# Altium schematic sheet TIDA-00367_REV2.SchDoc(TIDA-00367_REV2) (continued)

## Library data of the parts
C1 = QMK212B7102KD-T: CAP, CERM, 1000 pF, 250 V, +/- 10%, X7R, 0805; Manufacturer: Taiyo Yuden; Cap_Case: 0805; Cap_F: 0.000000001; CompType: Capacitor; Polarized: N; TaMax_C: 125; TaMin_C: -55; Tolerance_%: 10; U/M: EA; Value1: Unknown; Value2: 250V; VDC_V: 250; Component Kind: Standard; ComponentLink1Description: Datasheet link; ComponentLink1URL: http://www.yuden.co.jp/ut/product/pdf/dhmk_e.pdf; LatestRevisionDate: 2015-07-23; Library Name: Capacitor_Ceramic.IntLib; MountType: SMT; PackageReference: 0805; PartNumber: QMK212B7102KD-T; Published: 2008-06-19; RoHS: Y; Signal Integrity: Cap; Simulation: Cap; Supplier 1: Digi-Key; Supplier 2: Farnell; Supplier 3: Newark; Supplier Part Number 1: 587-1268-1-ND; Supplier Part Number 2: 1683683; Supplier Part Number 3: 77M8475; SymbolVersion: 2008-07-09; Technology: Ceramic; TempCo: X7R; ValueDisplayed: 1000pF; Publisher: Texas Instruments
C2 C18 C19 = DE1E3KX102MA5BA01: CAP, CERM, 1000 pF, 250 V, +/- 20%, E, Disc, 8x12mm; Manufacturer: MuRata; Cap_Case: DE_800x1200x800; Cap_F: 0.000000001; CompType: Capacitor; Polarized: N; TaMax_C: 85; TaMin_C: -25; Tolerance_%: 20; U/M: EA; Value1: Unknown; Value2: 250V; VDC_V: 250; Component Kind: Standard; ComponentLink1Description: Datasheet link; ComponentLink1URL: http://psearch.murata.com/capacitor/product/DE1E3KX102MA5BA01.pdf; LatestRevisionDate: 2014-12-18; Library Name: Capacitor_Ceramic.IntLib; MountType: TH; PackageReference: Disc, 8x12mm; PartNumber: DE1E3KX102MA5BA01; Published: 2008-06-19; RoHS: Y; Signal Integrity: Cap; Simulation: Cap; Supplier 1: Digi-Key; Supplier 2: Mouser; Supplier Part Number 1: 490-7045-ND; Supplier Part Number 2: 81-DE1E3KX102MA5BA01; SymbolVersion: 2008-07-09; Technology: Ceramic; TempCo: E; ValueDisplayed: 1000pF; Publisher: Texas Instruments
C3 = B32521C3474K: CAP FILM 0.47UF 20% 630VDC RAD; Manufacturer: TDK; Cap_Case: Radial 13x6x12mm; Cap_F: 0.00000047; CompType: Capacitor; Polarized: N; TaMax_C: 125; TaMin_C: -55; Tolerance_%: 10; U/M: EA; Value1: Unknown; Value2: 630V; VDC_V: 630; Component Kind: Standard; ComponentLink1Description: Datasheet link; ComponentLink1URL: http://en.tdk.eu/inf/20/20/db/fc_2009/B32520_529.pdf; LatestRevisionDate: 2015-07-13; Library Name: Capacitor_Other.IntLib; MountType: TH; PackageReference: 13x12x6mm; PartNumber: B32922C3474M; RoHS: Y; Series: B32521; Signal Integrity: Cap; Simulation: Cap; Supplier 1: Digi-Key; Supplier 2: Mouser; Supplier Part Number 1: 495-2322-ND; SymbolVersion: 2008-07-09; Technology: Film; Value: 0.47uF; Publisher: Texas Instruments
C4 = DE1E3KX222MA5BA01: CAP CER 6800PF 1KV X7R RADIAL; Manufacturer: MuRata; Cap_Case: CAPRD1000W60D1000H1400; Cap_F: 0.0000000022; CompType: Capacitor; Polarized: N; TaMax_C: 85; TaMin_C: -25; TempCo: E; Tolerance_%: 20; U/M: EA; Value: 2200pF; Value1: Unknown; Component Kind: Standard; ComponentLink1Description: Datasheet link; ComponentLink1URL: http://psearch.murata.com/capacitor/product/DE1E3KX222MA5BA01.pdf; LatestRevisionDate: 2015-07-23; Library Name: Capacitor_Ceramic.IntLib; MountType: TH; PackageReference: Disc 10x8mm; PartNumber: RDER73A682K3K1H03B; Published: 2008-06-19; RoHS: Y; Signal Integrity: Cap; Simulation: Cap; Supplier 1: Digi-Key; Supplier Part Number 1: 490-8956-ND; SymbolVersion: 2008-07-09; Technology: Ceramic; Value2: 1KV; VDC_V: 1KV; Publisher: Texas Instruments
C5 = B32653A224J: CAP, Film, 0.22 µF, 1000 V, +/- 5%, TH; Manufacturer: TDK; Cap_Case: 26.5x20.5x11mm; Cap_F: 0.00000022; CompType: Capacitor; Polarized: N; TaMax_C: 110; TaMin_C: -55; Tolerance_%: 5; U/M: EA; Value: 0.22uF; Value1: Unknown; Value2: 1000V; VDC_V: 1000; Component Kind: Standard; ComponentLink1Description: Datasheet link; ComponentLink1URL: http://www.epcos.com/inf/20/20/db/fc_2009/MKP_B32651_656.pdf; LatestRevisionDate: 2015-07-13; Library Name: Capacitor_Other.IntLib; MountType: TH; PackageReference: 26.50x20.50x11mm; PartNumber: B32653A224J; RoHS: Y; Series: B32653; Signal Integrity: Cap; Simulation: Cap; Supplier 1: Digi-Key; Supplier 2: Mouser; Supplier Part Number 1: 495-4423-ND; Supplier Part Number 2: 871-B32653A224J; SymbolVersion: 2008-07-09; Technology: Film; Publisher: Texas Instruments
C6 = VJ1206A102JXGAT5Z: CAP, CERM, 1000 pF, 1000 V, +/- 5%, C0G/NP0, 1206; Manufacturer: Vishay-Vitramon; Cap_F: 0.000000001; CompType: Capacitor; Polarized: N; TaMax_C: 125; TaMin_C: -55; Tolerance_%: 5; U/M: EA; Value1: Unknown; Value2: 1000V; VDC_V: 1000; Cap_Case: 1206; Component Kind: Standard; ComponentLink1Description: Datasheet link; ComponentLink1URL: http://www.vishay.com/docs/45199/commseri.pdf; LatestRevisionDate: 2015-02-10; Library Name: Capacitor_Ceramic.IntLib; MountType: SMT; PackageReference: 1206; PartNumber: VJ1206A102JXGAT5Z; Published: 2008-06-19; RoHS: Y; Signal Integrity: Cap; Simulation: Cap; Supplier 1: Digi-Key; Supplier 2: Mouser; Supplier Part Number 1: 720-1012-1-ND; Supplier Part Number 2: 77-VJ1206A102JXGAT5Z; SymbolVersion: 2008-07-09; Technology: Ceramic; TempCo: C0G/NP0; ValueDisplayed: 1000pF; Publisher: Texas Instruments
C7 C8 = ESMQ451VSN151MQ25S: CAP, AL, 150 µF, 400 V, +/- 20%, TH; Manufacturer: Chemi-Con; Cap_Case: 25.4x25mm; Cap_F: 0.00015; CompType: Capacitor; IRMS_A: 1.19; Polarized: Y; TaMax_C: 85; TaMin_C: -25; Tolerance_%: 20; U/M: EA; Value: 150uF; Value1: Unknown; Value2: 450V; VDC_V: 450; Component Kind: Standard; ComponentLink1Description: Datasheet link; ComponentLink1URL: http://www.chemi-con.co.jp/e/catalog/pdf/al-e/al-all-e1001o-150101.pdf; LatestRevisionDate: 2015-06-02; Library Name: Capacitor_Aluminum.IntLib; MountType: TH; PackageReference: 25.4x25mm; PartNumber: 450BXW150MEFC18X45; RoHS: Y; Series: TPF; Signal Integrity: Cap; Simulation: Cap; Supplier 1: Digi-Key; Supplier Part Number 1: 1189-2853-ND; SymbolVersion: 2008-07-09; Technology: AL; Publisher: Texas Instruments
C9 = B32922C3224M: CAP, Film, 0.22 µF, 630 V, +/- 20%, TH; Manufacturer: TDK; Cap_F: 0.00000022; CompType: Capacitor; Polarized: N; TaMax_C: 110; Tolerance_%: 20; U/M: EA; Value: 0.22uF; Value1: Unknown; Value2: 630V; VDC_V: 630; Component Kind: Standard; ComponentLink1Description: Datasheet link; ComponentLink1URL: http://en.tdk.eu/inf/20/20/db/fc_2009/X2_B32921_928.pdf; LatestRevisionDate: 2015-05-18; Library Name: Capacitor_Other.IntLib; MountType: TH; PackageReference: B32922_12.5mm; PartNumber: B32922C3224M; RoHS: Y; Series: B32922; Signal Integrity: Cap; Simulation: Cap; Supplier 1: Farnell; Supplier Part Number 1: 1112842; SymbolVersion: 2008-07-09; Technology: Film; Publisher: Texas Instruments
C11-C13 = EEU-FR1V102B: CAP, AL, 1000 µF, 35 V, +/- 20%, 0.018 ohm, TH; Manufacturer: Panasonic; Cap_Case: 12.5x20mm; Cap_F: 0.001; CompType: Capacitor; ESR_ohm: 0.018; IRMS_A: 2.6; Polarized: Y; TaMax_C: 105; TaMin_C: -40; Tolerance_%: 20; U/M: EA; Value: 1000uF; Value2: 35V; VDC_V: 35; Component Kind: Standard; ComponentLink1Description: Datasheet link; ComponentLink1URL: http://industrial.panasonic.com/lecs/www-data/pdf/ABA0000/ABA0000CE132.pdf; LatestRevisionDate: 2015-07-24; Library Name: Capacitor_Aluminum.IntLib; MountType: TH; PackageReference: D12.5xL20mm; PartNumber: EEU-FR1V102B; RoHS: Y; Series: FR; Signal Integrity: Cap; Simulation: Cap; Supplier 1: Digi-Key; Supplier 2: Mouser; Supplier Part Number 1: P15382CT-ND; Supplier Part Number 2: 667-EEU-FR1V102B; SymbolVersion: 2008-07-09; Technology: AL; Value1: 0.018 ohm; Publisher: Texas Instruments
C15 C20 = EKY-350ELL221MH15D: CAP, AL, 220 µF, 35 V, +/- 20%, 0.087 ohm, TH; Manufacturer: Chemi-Con; Cap_Case: 8x15; Cap_F: 0.00022; CompType: Capacitor; ESR_ohm: 0.087; IRMS_A: 0.84; Polarized: Y; TaMax_C: 105; TaMin_C: -40; Tolerance_%: 20; U/M: EA; Value: 220uF; Value2: 35V; VDC_V: 35; Component Kind: Standard; ComponentLink1Description: Datasheet link; ComponentLink1URL: http://www.chemi-con.co.jp/e/catalog/pdf/al-e/al-sepa-e/004-lead/al-ky-e-140701.pdf; LatestRevisionDate: 2015-06-02; Library Name: Capacitor_Aluminum.IntLib; MountType: TH; PackageReference: Cap 8x15mm; PartNumber: EKY-350ELL221MH15D; RoHS: Y; Series: KY; Signal Integrity: Cap; Simulation: Cap; Supplier 1: Digi-Key; Supplier 2: Mouser; Supplier 3: Newark; Supplier Part Number 1: 565-1573-ND; Supplier Part Number 2: 661-EKY350ELL221MH1; Supplier Part Number 3: 23K3843; SymbolVersion: 2008-07-09; Technology: AL; Value1: 0.087 ohm; Publisher: Texas Instruments
C16 C17 C28 C29 = DE1E3KX222MA4BL01: CAP, CERM, 2200 pF, 250 V, +/- 20%, E, Cap, 7x12x9 mm; Manufacturer: MuRata; Cap_Case: CAPRD1000W60D900H1200; Cap_F: 0.0000000022; CompType: Capacitor; Polarized: N; TaMax_C: 85; TaMin_C: -25; Tolerance_%: 20; U/M: EA; Value1: Unknown; Value2: 250V; VDC_V: 250; Component Kind: Standard; ComponentLink1Description: Datasheet link; ComponentLink1URL: http://psearch.murata.com/capacitor/product/DE1E3KX222MA4BL01.pdf?; LatestRevisionDate: 2014-12-18; Library Name: Capacitor_Ceramic.IntLib; MountType: TH; PackageReference: Cap, 7x12x9 mm; PartNumber: DE1E3KX222MA4BL01; Published: 2008-06-19; RoHS: Y; Signal Integrity: Cap; Simulation: Cap; Supplier 1: Digi-Key; Supplier 2: Mouser; Supplier 3: Farnell; Supplier Part Number 1: 490-7047-ND; Supplier Part Number 2: 81-DE1E3KX222MA4BL01; Supplier Part Number 3: 1515821; SymbolVersion: 2008-07-09; Technology: Ceramic; TempCo: E; ValueDisplayed: 2200pF; Publisher: Texas Instruments
C21 = ECE-A1CKS100: CAP, AL, 10 µF, 16 V, +/- 20%,  ohm, TH; Manufacturer: Panasonic; Cap_Case: 4x5mm; Cap_F: 0.00001; CompType: Capacitor; IRMS_A: 0.028; Polarized: Y; TaMax_C: 85; TaMin_C: -40; Tolerance_%: 20; U/M: EA; Value: 10uF; Value1: Unknown; Value2: 16V; VDC_V: 16; Component Kind: Standard; ComponentLink1Description: Datasheet link; ComponentLink1URL: http://industrial.panasonic.cn/lecs/www-data/pdf/ABA0000/ABA0000CE15.pdf; LatestRevisionDate: 2015-07-24; Library Name: Capacitor_Aluminum.IntLib; MountType: TH; PackageReference: D4xL5mm; PartNumber: ECE-A1CKS100; RoHS: Y; Series: KS; Signal Integrity: Cap; Simulation: Cap; Supplier 1: Digi-Key; Supplier 2: Mouser; Supplier Part Number 1: P966-ND; Supplier Part Number 2: 667-ECE-A1CKS100; SymbolVersion: 2008-07-09; Technology: AL; Publisher: Texas Instruments
C22 = 06035C104KAT2A: CAP, CERM, 0.1uF, 50V, +/-10%, X7R, 0603; Manufacturer: AVX; Cap_F: 0.0000001; CompType: Capacitor; Polarized: N; TaMax_C: 125; TaMin_C: -55; Tolerance_%: 10; U/M: EA; Value1: Unknown; VDC_V: 50; Cap_Case: 0603; Component Kind: Standard; ComponentLink1Description: Datasheet link; ComponentLink1URL: http://www.avx.com/docs/Catalogs/cx7r.pdf; LatestRevisionDate: 2013-04-19; LatestRevisionNote: Changed publisher; Library Name: Capacitor_Ceramic.IntLib; MountType: SMT; PackageReference: 0603; PartNumber: 06035C104KAT2A; Published: 2008-06-19; RoHS: Y; Signal Integrity: Cap; Simulation: Cap; Supplier 1: Digi-Key; Supplier 2: Mouser; Supplier 3: Farnell; Supplier Part Number 1: 478-5052-1-ND; Supplier Part Number 2: 581-06035C104KAT2A; Supplier Part Number 3: 1301804; SymbolVersion: 2008-07-09; Technology: Ceramic; TempCo: X7R; Value: 0.1uF; Value2: 50V; Publisher: Texas Instruments
C23 = 35ZLJ47MTA5X11: CAP, AL, 47 µF, 35 V, +/- 20%, 0.4 ohm, TH; Manufacturer: Rubycon; Cap_Case: 5x11mm; Cap_F: 0.000047; CompType: Capacitor; ESR_ohm: 0.4; IRMS_A: 0.45; Polarized: Y; TaMax_C: 105; TaMin_C: -40; Tolerance_%: 20; U/M: EA; Value: 47uF; Value1: 0.4 ohm; Value2: 35V; VDC_V: 35; Component Kind: Standard; ComponentLink1Description: Datasheet link; ComponentLink1URL: http://www.rubycon.co.jp/en/catalog/e_pdfs/aluminum/e_ZLJ.pdf; LatestRevisionDate: 2015-06-02; Library Name: Capacitor_Aluminum.IntLib; MountType: TH; PackageReference: D5xL11mm; PartNumber: 35ZLJ47MTA5X11; RoHS: Y; Series: ZLJ; Signal Integrity: Cap; Simulation: Cap; Supplier 1: Digi-Key; Supplier Part Number 1: 1189-1630-1-ND; SymbolVersion: 2008-07-09; Technology: AL; Publisher: Texas Instruments
C24 = 08055C105KAT2A: CAP, CERM, 1 µF, 50 V, +/- 10%, X7R, 0805; Manufacturer: AVX; Cap_Case: 0805; Cap_F: 0.000001; CompType: Capacitor; Polarized: N; TaMax_C: 125; TaMin_C: -55; Tolerance_%: 10; U/M: EA; Value: 1uF; Value1: Unknown; Value2: 50V; VDC_V: 50; Component Kind: Standard; ComponentLink1Description: Datasheet link; ComponentLink1URL: http://www.avx.com/docs/Catalogs/cx7r.pdf; LatestRevisionDate: 2014-12-18; Library Name: Capacitor_Ceramic.IntLib; MountType: SMT; PackageReference: 0805; PartNumber: 08055C105KAT2A; Published: 2008-06-19; RoHS: Y; Signal Integrity: Cap; Simulation: Cap; Supplier 1: Digi-Key; Supplier 2: Mouser; Supplier 3: Farnell; Supplier Part Number 1: 478-7956-1-ND; Supplier Part Number 2: 581-08055C105KAT2A; Supplier Part Number 3: 2094043; SymbolVersion: 2008-07-09; Technology: Ceramic; TempCo: X7R; Publisher: Texas Instruments
C25 = 08055C102KAT2A: CAP, CERM, 1000 pF, 50 V, +/- 10%, X7R, 0805; Manufacturer: AVX; Cap_Case: 0805; Cap_F: 0.000000001; CompType: Capacitor; ESR_ohm: 0.29; Polarized: N; TaMax_C: 125; TaMin_C: -55; Tolerance_%: 10; U/M: EA; Value1: 0.29 ohm; Value2: 50V; VDC_V: 50; Component Kind: Standard; ComponentLink1Description: Datasheet link; ComponentLink1URL: http://www.avx.com/docs/masterpubs/smccp.pdf; LatestRevisionDate: 2014-12-18; Library Name: Capacitor_Ceramic.IntLib; MountType: SMT; PackageReference: 0805; PartNumber: 08055C102KAT2A; Published: 2008-06-19; RoHS: Y; Signal Integrity: Cap; Simulation: Cap; Supplier 1: Digi-Key; Supplier 2: Mouser; Supplier 3: Farnell; Supplier Part Number 1: 478-1371-1-ND; Supplier Part Number 2: 581-08055C102K; Supplier Part Number 3: 499201; SymbolVersion: 2008-07-09; Technology: Ceramic; TempCo: X7R; ValueDisplayed: 1000pF; Publisher: Texas Instruments
C30 = DE1E3KX222MA5BA01: CAP CER 0.022UF 1KV X7R RADIAL; Manufacturer: MuRata; Cap_Case: CAPRD1000W60D1000H1400; Cap_F: 0.0000000022; CompType: Capacitor; Polarized: N; TaMax_C: 85; TaMin_C: -25; TempCo: E; Tolerance_%: 20; U/M: EA; Value1: Unknown; Component Kind: Standard; ComponentLink1Description: Datasheet link; ComponentLink1URL: http://psearch.murata.com/capacitor/product/DE1E3KX222MA5BA01.pdf; LatestRevisionDate: 2015-07-23; Library Name: Capacitor_Ceramic.IntLib; MountType: TH; PackageReference: Disc 10x8mm; PartNumber: RDER73A223K3M1H03A; Published: 2008-06-19; RoHS: Y; Signal Integrity: Cap; Simulation: Cap; Supplier 1: Digi-Key; Supplier Part Number 1: 490-9278-3-ND; SymbolVersion: 2008-07-09; Technology: Ceramic; Value2: 1KV; ValueDisplayed: 22nF; VDC_V: 1KV; Publisher: Texas Instruments
D1 = GBU4K-E3/45: Diode, Switching-Bridge, 800 V, 4 A, TH; Manufacturer: Vishay-Semiconductor; Io_A: 4; RJC_CperW: 4.2; TJmax_C: 150; U/M: EA; Value: 800V; Value1: 4A; Value2: 1V; Vf_V: 1; Vr_V: 800; Component Kind: Standard; ComponentLink1Description: Datasheet link; ComponentLink1URL: http://www.vishay.com/docs/88614/gbu4a.pdf; CompType: Diode; LatestRevisionDate: 2015-05-28; Library Name: Diode.IntLib; MountType: TH; PackageReference: GBU; PartNumber: GBU4K-E3/45; Published: 2008-06-26; RoHS: Y; Supplier 1: Digi-Key; Supplier 2: Mouser; Supplier 3: Farnell; Supplier Part Number 1: GBU4K-E3/45GI-ND; Supplier Part Number 2: 625-GBU4K-E3; Supplier Part Number 3: 9549463; SymbolVersion: 2009-10-05; Type: Switching-Bridge; Publisher: Texas Instruments
D2 = SBR2060CT: Diode, Super Barrier Rectifier, 60 V, 10 A, TO-220AB; Manufacturer: Diodes Inc.; Io_A: 10; RJC_CperW: 2; TJmax_C: 150; U/M: EA; Value: 60V; Value1: 10A; Value2: 0.7V; Vf_V: 0.7; Vr_V: 60; Component Kind: Standard; ComponentLink1Description: Datasheet link; ComponentLink1URL: http://diodes.com/datasheets/SBR2060.pdf; CompType: Diode; LatestRevisionDate: 2015-07-24; Library Name: Diode.IntLib; MountType: SMT; PackageReference: TO-220AB; PartNumber: SBR20150CT; Published: 2008-06-26; RoHS: Y; Signal Integrity: Diode; Supplier 1: Digi-Key; Supplier 2: Mouser; Supplier Part Number 1: 621-SBR20150CT; Supplier Part Number 2: 621-SBR2060CT; SymbolVersion: 2009-09-25; Type: Super Barrier Rectifier; Publisher: Texas Instruments
D3 = 1N5406: DIODE GEN PURP 1KV 3A DO201AD; Manufacturer: Vishay-Semiconductor; Io_A: 3; TJmax_C: 150; Type: Switching-Bridge; U/M: EA; Value: 1000V; Value2: 1.2V; Vf_V: 1.2; Component Kind: Standard; ComponentLink1Description: Datasheet link; ComponentLink1URL: http://www.vishay.com/docs/88516/1n5400.pdf; CompType: Diode; LatestRevisionDate: 2015-07-16; Library Name: Diode.IntLib; MountType: TH; PackageReference: DO-201AD; PartNumber: UF5408-TP; Published: 2008-06-26; RoHS: Y; Signal Integrity: Diode; Supplier 1: Digi-Key; Supplier 2: Mouser; Supplier 3: Farnell; Supplier Part Number 1: UF5408-TPMSCT-ND; SymbolVersion: 2008-12-08; Value1: 3A; Vr_V: 1000V; Publisher: Texas Instruments
D4 = BZT52C12T-7: Diode, Zener, 12 V, 300 mW, SOD-523; Manufacturer: Diodes Inc.; Power_W: 0.3; RJA_CperW: 417; SymbolCreator: a0412235; U/M: EA; Value1: 0.3W; Vz_V: 12; Component Kind: Standard; ComponentLink1Description: Datasheet link; ComponentLink1URL: http://www.diodes.com/datasheets/ds30502.pdf; CompType: Zener; LatestRevisionDate: 2015-05-14; LatestRevisionNote: Updated Supplier Data; Library Name: ZenerDiodes.IntLib; MountType: SMT; PackageReference: SOD-523; PartNumber: BZT52C12T-7; Published: 2008-10-08; RoHS: Y; Signal Integrity: Diode; Supplier 1: Digi-Key; Supplier 2: Mouser; Supplier Part Number 1: BZT52C12T-7DICT-ND; Supplier Part Number 2: 621-BZT52C12T-7; SymbolVersion: 2010-08-25; Vr_V: N/A; Publisher: Texas Instruments
D5 = B1100B-13-F: Diode, Schottky, 100 V, 1 A, SMB; Manufacturer: Diodes Inc.; Io_A: 1; RJC_CperW: 25; TJmax_C: 150; U/M: EA; Value: 100V; Value1: 1A; Value2: 0.79V; Vf_V: 0.79; Vr_V: 100; Component Kind: Standard; ComponentLink1Description: Datasheet link; ComponentLink1URL: http://www.diodes.com/datasheets/ds30018.pdf; CompType: Diode; LatestRevisionDate: 2015-05-28; Library Name: Diode.IntLib; MountType: SMT; PackageReference: SMB; PartNumber: STPS1H100U; Published: 2008-06-26; RoHS: Y; Signal Integrity: Diode; Simulation: DI_B1100B; Supplier 1: Digi-Key; Supplier 2: Mouser; Supplier Part Number 1: 497-3210-2-ND; SymbolVersion: 2008-12-08; Type: Schottky; Publisher: Texas Instruments
D6 = SMCJ100A: Diode, TVS, Uni, 120 V, 1500 W, SMC; Manufacturer: Littelfuse; Power_W: 1500; RJA_CperW: 75; U/M: EA; Value1: 1500W; Vr_V: 100; Component Kind: Standard; ComponentLink1Description: Datasheet link; ComponentLink1URL: http://www.littelfuse.com/data/en/Data_Sheets/Littelfuse_TVS_Diode_SMCJ.pdf; CompType: TVS; LatestRevisionDate: 2015-07-21; LatestRevisionNote: Updated Supplier Data; Library Name: ZenerDiodes.IntLib; MountType: SMT; PackageReference: SMC; PartNumber: 1.5KE120A-E3/54; Published: 2008-10-08; RoHS: Y; Supplier 1: Digi-Key; Supplier 2: Mouser; Supplier 3: Newark; Supplier Part Number 1: 1.5KE120A-E3/54GITR-ND; SymbolVersion: 2012-01-13; Vz_V: N/A; Publisher: Texas Instruments
D7 D9 = CGRM4007-G: Diode, P-N, 1000 V, 1 A, 3.9x1.7x1.8mm; Manufacturer: Comchip Technology; Io_A: 1; TJmax_C: 150; U/M: EA; Value: 1000V; Value1: 1A; Value2: 1.1V; Vf_V: 1.1; Vr_V: 1000; Component Kind: Standard; ComponentLink1Description: Datasheet link; ComponentLink1URL: http://www.comchiptech.com/cms/UserFiles/CGRM4001-G%20Thru.%20CGRM4007-G%20RevD.pdf; CompType: Diode; LatestRevisionDate: 2015-05-28; Library Name: Diode.IntLib; MountType: SMT; PackageReference: 3.9x1.7x1.8mm; PartNumber: CGRM4007-G; Published: 2008-06-26; RoHS: Y; Signal Integrity: Diode; Supplier 1: Digi-Key; Supplier 2: Mouser; Supplier Part Number 1: 641-1330-1-ND; Supplier Part Number 2: 750-CGRM4007-G; SymbolVersion: 2008-12-08; Type: P-N; Publisher: Texas Instruments
D8 = 1N4448WX-TP: Diode, Switching, 75 V, 0.25 A, SOD-323; Manufacturer: Micro Commercial Components; Io_A: 0.25; RJC_CperW: 625; TJmax_C: 150; U/M: EA; Value: 75V; Value1: 0.25A; Vr_V: 75; Component Kind: Standard; ComponentLink1Description: Datasheet link; ComponentLink1URL: http://www.mccsemi.com/up_pdf/1N4448WX%28SOD-323%29.PDF; CompType: Diode; LatestRevisionDate: 2014-12-22; Library Name: Diode.IntLib; MountType: SMT; PackageReference: SOD-323; PartNumber: 1N4448WX; Published: 2008-06-26; RoHS: Y; Signal Integrity: Diode; Supplier 1: Digi-Key; Supplier 2: Mouser; Supplier 3: Newark; Supplier Part Number 1: 1N4448WXTPMSCT-ND; Supplier Part Number 2: 833-1N4448WX-TP; Supplier Part Number 3: 69R6669; SymbolVersion: 2008-12-08; Type: Switching; Value2: V; Publisher: Texas Instruments
D10 = 1N5819HW-7-F: Diode, Schottky, 40 V, 1 A, SOD-123; Manufacturer: Diodes Inc.; Io_A: 1; TJmax_C: 125; U/M: EA; Value: 40V; Value1: 1A; Value2: 0.45V; Vf_V: 0.45; Vr_V: 40; Component Kind: Standard; ComponentLink1Description: Datasheet link; ComponentLink1URL: http://www.diodes.com/datasheets/ds30217.pdf; CompType: Diode; LatestRevisionDate: 2015-02-10; Library Name: Diode.IntLib; MountType: SMT; PackageReference: SOD-123; PartNumber: 1N5819HW-7-F; Published: 2008-06-26; RoHS: Y; Signal Integrity: Diode; Simulation: DI_1N5819HW; Supplier 1: Digi-Key; Supplier 2: Mouser; Supplier 3: Farnell; Supplier Part Number 1: 1N5819HW-FDICT-ND; Supplier Part Number 2: 621-1N5819HW-F; Supplier Part Number 3: 1773475; SymbolVersion: 2008-12-08; Type: Schottky; Publisher: Texas Instruments
D12 = BAV70-V: Diode, Switching, 70 V, 0.25 A, SOT-23; Manufacturer: Vishay-Semiconductor; Io_A: 0.25; RJC_CperW: 430; SymbolCreator: a0412235; TJmax_C: 150; U/M: EA; Value: 70V; Value1: 0.25A; Value2: 1.25V; Vf_V: 1.25; Vr_V: 70; Component Kind: Standard; ComponentLink1Description: Datasheet link; ComponentLink1URL: http://www.vishay.com/docs/85546/bav70-v.pdf; CompType: Diode; LatestRevisionDate: 2014-12-22; Library Name: Diode.IntLib; MountType: SMT; PackageReference: SOT-23; PartNumber: BAV70-V; Published: 2008-06-26; RoHS: Y; Supplier 1: Digi-Key; Supplier 2: Mouser; Supplier 3: Newark; Supplier Part Number 1: BAV70-V-GS08CT-ND; Supplier Part Number 2: 625-BAV70-V; Supplier Part Number 3: 30K5633; SymbolVersion: 2013-02-26; Type: Switching; Publisher: Texas Instruments
D13 = RF081M2STR: Diode, Fast Rectifier, 200 V, 0.8 A, SOD-123; Manufacturer: Rohm; Io_A: 0.8; TJmax_C: 150; U/M: EA; Value: 200V; Value1: 0.8A; Value2: 0.95V; Vf_V: 0.95; Vr_V: 200; Component Kind: Standard; ComponentLink1Description: Datasheet link; ComponentLink1URL: http://rohmfs.rohm.com/en/products/databook/datasheet/discrete/diode/fast_recovery/rf081m2s.pdf; CompType: Diode; LatestRevisionDate: 2015-07-24; Library Name: Diode.IntLib; MountType: SMT; PackageReference: SOD-123; PartNumber: RF081M2STR; Published: 2008-06-26; RoHS: Y; Signal Integrity: Diode; Supplier 1: Digi-Key; Supplier 2: Mouser; Supplier Part Number 1: RF081M2SCT-ND; Supplier Part Number 2: 755-RF081M2STR; SymbolVersion: 2008-12-08; Type: Fast Rectifier; Publisher: Texas Instruments
F1 = 37215000001: Fuse, 5 A, 250 V, TH; Manufacturer: Littelfuse; Irating_A: 5; MeltingTyp: 94; OpeningTime_100%_min: 4; OpeningTime_200%_max: 2; Soldering_Wave_MaxTemp_C: 260; U/M: EA; VAC_V: 250; Vdrop: 0.08; Component Kind: Standard; ComponentLink1Description: Datasheet link; ComponentLink1URL: http://www.littelfuse.com/data/en/Data_Sheets/Littelfuse_Fuse_372.pdf; CompType: Fuse; LatestRevisionDate: 2015-05-05; Library Name: Fuse.IntLib; MountType: TH; PackageReference: TR5 fuse 8.5mm DIA; PartNumber: 37215000001; Published: 2009-03-05; RoHS: Y; Soldering_Wave_MaxTime_s: 5; Supplier 1: Digi-Key; Supplier 2: Mouser; Supplier Part Number 1: WK4263CT-ND; Supplier Part Number 2: 576-37215000001; SymbolVersion: 2008-07-09; VDC_V: 250; Publisher: Texas Instruments
H1 = 530614B00000G: Heat Sink, TO-220, TH; Manufacturer: Aavid; SymbolCreator: a0412235; Component Kind: Standard; ComponentLink1Description: Datasheet Link; ComponentLink1URL: http://www.aavid.com/sites/default/files/literature/Aavid-Board-Level-Heatsinks-Catalog.pdf#page=40; CompType: Heat Sink; LatestRevisionDate: 2015-07-19; Library Name: Hardware.IntLib; MountType: N/A; PackageReference: TO-220 Heat Sink; PartNumber: 530614B00000G; RoHS: Y; Supplier 1: Digi-Key; Supplier 2: Mouser; Supplier 3: Farnell; Supplier Part Number 1: HS278-ND; Supplier Part Number 2: 532-530614B00; Supplier Part Number 3: 1346242; SymbolVersion: 2013-07-05; Publisher: Texas Instruments
H2 H3 = 531002B02500G: HEATSINK TO-220 W/PINS 1" TALL; Manufacturer: Aavid; SymbolCreator: a0799628; Component Kind: Standard; ComponentLink1Description: Datasheet Link; ComponentLink1URL: http://www.aavid.com/sites/default/files/literature/Aavid-Board-Level-Heatsinks-Catalog.pdf#page=59; CompType: Heat Sink; LatestRevisionDate: 2015-07-23; Library Name: Hardware.IntLib; MountType: TH; PackageReference: TH, 2-Leads, Body 34.92x12.7mm, Pin Spacing 25.4mm; PartNumber: 531002B02500G; RoHS: Y; Supplier 1: Digi-Key; Supplier 2: Mouser; Supplier 3: Farnell; Supplier Part Number 1: HS351-ND; Supplier Part Number 2: 532-531002B25G; Supplier Part Number 3: 1611438; SymbolVersion: 2013-12-05; Publisher: Texas Instruments
J1 = OSTTA034163: Terminal Block, 3x1, 5.08 mm, TH; Manufacturer: On-Shore Technology; SymbolCreator: a0412235; U/M: EA; Component Kind: Standard; ComponentLink1Description: Datasheet Link; ComponentLink1URL: http://www.on-shore.com/sites/default/files/manuals/OSTTAXX4163.pdf; CompType: Terminal Block; LatestRevisionDate: 2014-11-22; Library Name: Connectors.IntLib; MountType: TH; PackageReference: 3x1 Terminal Block; PartNumber: OSTTA034163; RoHS: Y; Supplier 1: Digi-Key; Supplier Part Number 1: ED2581-ND; SymbolVersion: 2013-10-03; Publisher: Texas Instruments
J2 = 1757242: Header (Shrouded), 5.08 mm, 2x1, Tin, R/A, TH; Manufacturer: Phoenix Contact; SymbolCreator: a0412235; U/M: EA; Component Kind: Standard; ComponentLink1Description: Datasheet Link; ComponentLink1URL: https://www.phoenixcontact.com/online/portal/us?uri=pxc-oc-itemdetail%3Apid=1757242&library=usen&pdfmode=direct&pdflanguage=en; CompType: Header; LatestRevisionDate: 2014-11-22; Library Name: Connectors.IntLib; MountType: TH; PackageReference: Header, 5.08 mm, 2x1, R/A, TH; PartNumber: 1757242; RoHS: Y; Supplier 1: Digi-Key; Supplier 2: Mouser; Supplier 3: Farnell; Supplier Part Number 1: 277-1106-ND; Supplier Part Number 2: 651-1757242; Supplier Part Number 3: 3705171; SymbolVersion: 2013-10-31; Publisher: Texas Instruments
J4 = OSTTC022162: Terminal Block, 2-pole, 200mil, TH; Manufacturer: On-Shore Technology; SymbolCreator: a0412235; U/M: EA; Component Kind: Standard; ComponentLink1Description: Datasheet Link; ComponentLink1URL: http://www.on-shore.com/sites/default/files/manuals/osttcxx2162.pdf; CompType: Terminal Block; LatestRevisionDate: 2014-12-23; Library Name: Connectors_Other.IntLib; MountType: TH; PackageReference: THD, 2-Leads, Body 10.16x7.6mm, Pitch 5.08mm; PartNumber: OSTTC022162; RoHS: Y; Supplier 1: Digi-Key; Supplier Part Number 1: ED2609-ND; SymbolVersion: 2013-09-04; Publisher: Texas Instruments
L1 L3 = 744824310: Coupled inductor, 10 mH, 3 A, 0.040 ohm, +/- 30%, TH; Manufacturer: Abracon; DCR_ohm: 0.105; Ind_H: 10.0E-3; Isat: 3; SymbolCreator: a0799628; Tolerance_%: 30; Component Kind: Standard; ComponentLink1Description: Datasheet URL; ComponentLink1URL: http://katalog.we-online.de/pbs/datasheet/744824310.pdf; CompType: Coupled Inductor; LatestRevisionDate: 2015-07-23; Library Name: Coupled_Inductors.IntLib; MountType: TH; PackageReference: 18.5x33x27.5 mm; PartNumber: ALFT-04-9; Published: 2008-05-16; RoHS: Y; Supplier 1: Digi-Key; Supplier Part Number 1: 535-12487-ND; SymbolVersion: 2013-07-25; ValueDisplayed: 10mH; Publisher: Texas Instruments
L2 = 7447462010: Inductor, Unshielded Drum Core, Ferrite, 1 µH, 8 A, 0.006 ohm, TH; Manufacturer: Wurth Elektronik; DCR_ohm: 0.006; Imax_A: 8; Ind_H: 1.0E-6; Irms: 8.5A @ 40C rise; Isat: 8A @ 10% inductance drop; Value: 1uH; Value2: 8A; ValueDisplayed: 1µH; Component Kind: Standard; ComponentLink1Description: Datasheet link; ComponentLink1URL: http://katalog.we-online.de/kataloge/eisos/media/pdf/7447462010.pdf; CompType: Inductor; LatestRevisionDate: 2015-07-21; Library Name: Inductor_Wurth.IntLib; Material: Ferrite; MountType: TH; PackageReference: D6 x 8.5mm; PartNumber: 7447462010; Published: 2008-06-26; RoHS: Y; Signal Integrity: Inductor; Simulation: Inductor; Supplier 1: Digi-Key; Supplier Part Number 1: 732-2565-ND; SymbolVersion: 2008-07-23; Technology: Unshielded Drum Core; Value1: 0.006 ohm; Publisher: Texas Instruments
Q1 = 2N4401-AP, Micro Commercial Components: Transistor, NPN, 40 V, 0.5 A, TO-92AP; CompType: BJT; HfeMax: 300; IcRated_A: 0.5; NPN: 1; PNP: 0; TaMax_C: 150; TaMin_C: -55; U/M: EA; Value: 40 V; Value1: 0.5 A; Vceo_V: 40; Vcesat1_V: 0.75; Component Kind: Standard; ComponentLink1Description: Datasheet link; ComponentLink1URL: http://www.mccsemi.com/up_pdf/2N4401(TO-92).pdf; LatestRevisionDate: 2015-07-24; Library Name: Bipolar_Transistor.IntLib; Manufacturer: Micro Commercial Components; MountType: TH; PackageReference: TO-92AP; PartNumber: 2N4401-AP; Published: 2008-07-31; RoHS: Y; Supplier 1: Digi-Key; Supplier Part Number 1: 2N4401-APCT-ND; SymbolVersion: 2008-07-31; Value2: 300; Publisher: Texas Instruments
Q2 = IPP60R199CP: MOSFET, N-CH, 650 V, 16 A, TO-220AB; Alternate Manufacturer: None; Manufacturer: Infineon Technologies; ChType: N; IdsMax_A: 16; QgTyp_nC: 20; Rdson45_ohm: 0.225; U/M: EA; Value: 650V; Value1: 0.225 ohm; Value2: 20 nC; VdsMax_V: 650; Vgs_V: 3.5; Component Kind: Standard; ComponentLink1Description: Datasheet link; ComponentLink1URL: https://sps06.itg.ti.com/sites/KMS/crossdivteams/altium/docs/ComponentDatasheets/IPP60R199CP.pdf; CompType: MOSFET; LatestRevisionDate: 2015-06-01; LatestRevisionNote: Added parts.; Library Name: FETs.IntLib; MountType: TH; PackageReference: TO-220AB; PartNumber: IPP65R225C7XKSA1; Published: 2008-06-19; RoHS: Y; Supplier 1: Digi-Key; Supplier 2: Mouser; Supplier 3: Farnell; Supplier Part Number 1: IPP65R225C7XKSA1-ND; SymbolVersion: 2008-07-28; Publisher: Texas Instruments
R1 R21 R22 = CRCW120622R0JNEA: RES, 22, 5%, 0.25 W, 1206; Manufacturer: Vishay-Dale; CompType: Resistor; EIA_Code: E24; Power_W: 0.25; TempCo: 200 ppm/C; Tolerance_%: 5; U/M: EA; Value2: 0.25W; Value_Code: 22R0; VDC_V: 200; Component Kind: Standard; ComponentLink1Description: Datasheet link; ComponentLink1URL: http://www.vishay.com/docs/20035/dcrcwe3.pdf; LatestRevisionDate: 2015-05-30; Library Name: Resistor_1206.IntLib; MountType: SMT; PackageReference: 1206; PartNumber: CRCW120622R0JNEA; Published: 2008-06-26; Res_ohm: 22; RoHS: Y; Signal Integrity: Resistor; Simulation: Resistor; Supplier 1: Digi-Key; Supplier 2: Mouser; Supplier 3: Farnell; Supplier Part Number 1: 541-22ECT-ND; Supplier Part Number 2: 71-CRCW1206J-22-E3; Supplier Part Number 3: 1540006; SymbolVersion: 2009-05-29; Technology: Thick Film; Value1: 5%; ValueDisplayed: 22; Publisher: Texas Instruments
R2 = CRCW060310R0JNEA: RES, 10, 5%, 0.1 W, 0603; Manufacturer: Vishay-Dale; CompType: Resistor; EIA_Code: E24; Power_W: 0.1; TempCo: 200 ppm/C; Tolerance_%: 5; U/M: EA; Value2: 0.1W; Value_Code: 10R0; VDC_V: 75; Component Kind: Standard; ComponentLink1Description: Datasheet link; ComponentLink1URL: http://www.vishay.com/docs/20035/dcrcwe3.pdf; LatestRevisionDate: 2014-12-16; Library Name: Resistor_0603.IntLib; MountType: SMT; PackageReference: 0603; PartNumber: CRCW060310R0JNEA; Published: 2008-06-26; Res_ohm: 10; RoHS: Y; Signal Integrity: Resistor; Simulation: Resistor; Supplier 1: Digi-Key; Supplier 2: Mouser; Supplier 3: Farnell; Supplier Part Number 1: 541-10GCT-ND; Supplier Part Number 2: 71-CRCW0603J-10-E3; Supplier Part Number 3: 1514712; SymbolVersion: 2009-05-29; Technology: Thick Film; Value1: 5%; ValueDisplayed: 10; Publisher: Texas Instruments
R3 = CMF50200R00FHEB: RES, 100, 1%, 0.25 W, TH; Manufacturer: Ohmite; CompType: Resistor; EIA_Code: E96; Power_W: 0.25; Res_ohm: 200; TempCo: 50 ppm/C; Tolerance_%: 1; U/M: EA; Value: 200; Value2: 0.25W; Value_Code: 200R; ValueDisplayed: 100; Component Kind: Standard; ComponentLink1Description: Datasheet link; ComponentLink1URL: http://www.vishay.com/docs/31018/cmfind.pdf; LatestRevisionDate: 2015-04-11; Library Name: Resistor_TH.IntLib; MountType: TH; PackageReference: 1/2W Resistor; PartNumber: OF101JE; Published: 2013-01-08; RoHS: Y; Signal Integrity: Resistor; Simulation: Resistor; Supplier 1: Digi-Key; Supplier 2: Mouser; Supplier 3: Farnell; Supplier Part Number 1: OF101JE-ND; SymbolVersion: 2009-05-29; Technology: Metal Film; Value1: 1%; VDC_V: 200; Publisher: Texas Instruments
R4 R5 = CRCW060322K0JNEA: RES, 22 k, 5%, 0.1 W, 0603; Manufacturer: Vishay-Dale; CompType: Resistor; EIA_Code: E24; Power_W: 0.1; Res_ohm: 22000; TempCo: 200 ppm/C; Tolerance_%: 5; U/M: EA; Value2: 0.1W; Value_Code: 22k0; VDC_V: 75; Component Kind: Standard; ComponentLink1Description: Datasheet link; ComponentLink1URL: http://www.vishay.com/docs/20035/dcrcwe3.pdf; LatestRevisionDate: 2015-06-13; Library Name: Resistor_0603.IntLib; MountType: SMT; PackageReference: 0603; PartNumber: CRCW060322K0JNEA; Published: 2008-06-26; RoHS: Y; Signal Integrity: Resistor; Simulation: Resistor; Supplier 1: Digi-Key; Supplier 2: Mouser; Supplier 3: Farnell; Supplier Part Number 1: 541-22KGCT-ND; Supplier Part Number 2: 71-CRCW0603J-22K-E3; Supplier Part Number 3: 1514737; SymbolVersion: 2009-05-29; Technology: Thick Film; Value1: 5%; ValueDisplayed: 22k; Publisher: Texas Instruments
R6 R24 = CRCW08051K00JNEA: RES, 1.0 k, 5%, 0.125 W, 0805; Manufacturer: Vishay-Dale; CompType: Resistor; EIA_Code: E24; Power_W: 0.125; Res_ohm: 1000; TempCo: 200 ppm/C; Tolerance_%: 5; U/M: EA; Value2: 0.125W; Value_Code: 1k00; VDC_V: 150; Component Kind: Standard; ComponentLink1Description: Datasheet link; ComponentLink1URL: http://www.vishay.com/docs/20035/dcrcwe3.pdf; LatestRevisionDate: 2015-03-25; Library Name: Resistor_0805.IntLib; MountType: SMT; PackageReference: 0805; PartNumber: CRCW08051K00JNEA; Published: 2008-06-26; RoHS: Y; Signal Integrity: Resistor; Simulation: Resistor; Supplier 1: Digi-Key; Supplier 2: Mouser; Supplier 3: Farnell; Supplier Part Number 1: 541-1.0KACT-ND; Supplier Part Number 2: 71-CRCW0805J-1K-E3; Supplier Part Number 3: 1652937; SymbolVersion: 2009-05-29; Technology: Thick Film; Value1: 5%; ValueDisplayed: 1.0k; Publisher: Texas Instruments
R7 R8 R23 = CRCW1206100KJNEA: RES, 100 k, 5%, 0.25 W, 1206; Manufacturer: Vishay-Dale; CompType: Resistor; EIA_Code: E24; Power_W: 0.25; Res_ohm: 100000; TempCo: 200 ppm/C; Tolerance_%: 5; U/M: EA; Value2: 0.25W; VDC_V: 200; Component Kind: Standard; ComponentLink1Description: Datasheet link; ComponentLink1URL: http://www.vishay.com/docs/20035/dcrcwe3.pdf; LatestRevisionDate: 2015-05-30; Library Name: Resistor_1206.IntLib; MountType: SMT; PackageReference: 1206; PartNumber: CRCW1206100KJNEA; Published: 2008-06-26; RoHS: Y; Signal Integrity: Resistor; Simulation: Resistor; Supplier 1: Digi-Key; Supplier 2: Farnell; Supplier 3: Newark; Supplier Part Number 1: 541-100KECT-ND; Supplier Part Number 2: 1534576; Supplier Part Number 3: 59M6947; SymbolVersion: 2009-05-29; Technology: Thick Film; Value1: 5%; Value_Code: 100k; ValueDisplayed: 100k; Publisher: Texas Instruments
R9 = ERJ-6ENF3921V: RES, 3.92 k, 1%, 0.125 W, 0805; Manufacturer: Panasonic; CompType: Resistor; EIA_Code: E96; Power_W: 0.125; Res_ohm: 3920; TempCo: 100 ppm/C; Tolerance_%: 1; U/M: EA; Value2: 0.125W; Value_Code: 3k92; Component Kind: Standard; ComponentLink1Description: Datasheet link; ComponentLink1URL: http://www.panasonic.com/industrial/components/pdf/AOA0000CE2.pdf; LatestRevisionDate: 2014-12-17; Library Name: Resistor_0805.IntLib; MountType: SMT; PackageReference: 0805; PartNumber: ERJ-6ENF3921V; Published: 2008-06-26; RoHS: Y; Signal Integrity: Resistor; Simulation: Resistor; Supplier 1: Digi-Key; Supplier 2: Mouser; Supplier 3: Farnell; Supplier Part Number 1: P3.92KCCT-ND; Supplier Part Number 2: 667-ERJ-6ENF3921V; Supplier Part Number 3: 2057620; SymbolVersion: 2009-05-29; Technology: Thick Film; Value1: 1%; ValueDisplayed: 3.92k; Publisher: Texas Instruments
R10 = ERJ-6GEYJ4R7V: RES, 4.7, 5%, 0.125 W, 0805; Manufacturer: Panasonic; CompType: Resistor; EIA_Code: E24; Power_W: 0.125; TempCo: 600 ppm/C; Tolerance_%: 5; U/M: EA; Value2: 0.125W; Value_Code: 4R70; VDC_V: 150; Component Kind: Standard; ComponentLink1Description: Datasheet link; ComponentLink1URL: http://www.panasonic.com/industrial/components/pdf/AOA0000CE1.pdf; LatestRevisionDate: 2014-12-17; Library Name: Resistor_0805.IntLib; MountType: SMT; PackageReference: 0805; PartNumber: ERJ-6GEYJ4R7V; Published: 2008-06-26; RoHS: Y; Signal Integrity: Resistor; Simulation: Resistor; Supplier 1: Digi-Key; Supplier 2: Mouser; Supplier 3: Farnell; Supplier Part Number 1: P4.7ACT-ND; Supplier Part Number 2: 667-ERJ-6GEYJ4R7V; Supplier Part Number 3: 2057665; SymbolVersion: 2009-05-29; Technology: Thick Film; Value1: 5%; ValueDisplayed: 4.7; Publisher: Texas Instruments
R11 = CRCW040223K7FKED: RES, 23.7 k, 1%, 0.063 W, 0402; Manufacturer: Vishay-Dale; CompType: Resistor; EIA_Code: E96; Power_W: 0.063; Res_ohm: 23700; TempCo: 100 ppm/C; Tolerance_%: 1; U/M: EA; Value2: 0.063W; Value_Code: 23k7; VDC_V: 50; Component Kind: Standard; ComponentLink1Description: Datasheet link; ComponentLink1URL: http://www.vishay.com/docs/20035/dcrcwe3.pdf; LatestRevisionDate: 2015-05-27; Library Name: Resistor_0402.IntLib; MountType: SMT; PackageReference: 0402; PartNumber: CRCW040223K7FKED; Published: 2008-06-26; RoHS: Y; Signal Integrity: Resistor; Simulation: Resistor; Supplier 1: Digi-Key; Supplier 2: Mouser; Supplier 3: Farnell; Supplier Part Number 1: 541-23.7KLCT-ND; Supplier Part Number 2: 71-CRCW0402-23.7K-E3; Supplier Part Number 3: 1652776; SymbolVersion: 2009-05-29; Technology: Thick Film; Value1: 1%; ValueDisplayed: 23.7k; Publisher: Texas Instruments
R12 = ERJ-6GEYJ470V: RES, 47, 5%, 0.125 W, 0805; Manufacturer: Panasonic; CompType: Resistor; EIA_Code: E24; Power_W: 0.125; TempCo: 200 ppm/C; Tolerance_%: 5; U/M: EA; Value2: 0.125W; Value_Code: 47R0; VDC_V: 150; Component Kind: Standard; ComponentLink1Description: Datasheet link; ComponentLink1URL: http://www.panasonic.com/industrial/components/pdf/AOA0000CE1.pdf; LatestRevisionDate: 2014-12-17; Library Name: Resistor_0805.IntLib; MountType: SMT; PackageReference: 0805; PartNumber: ERJ-6GEYJ470V; Published: 2008-06-26; Res_ohm: 47; RoHS: Y; Signal Integrity: Resistor; Simulation: Resistor; Supplier 1: Digi-Key; Supplier 2: Mouser; Supplier 3: Farnell; Supplier Part Number 1: P47ACT-ND; Supplier Part Number 2: 667-ERJ-6GEYJ470V; Supplier Part Number 3: 2057676; SymbolVersion: 2009-05-29; Technology: Thick Film; Value1: 5%; ValueDisplayed: 47; Publisher: Texas Instruments
R13 R18 = CRCW0805100RFKEA: RES, 100, 1%, 0.125 W, 0805; Manufacturer: Vishay-Dale; CompType: Resistor; EIA_Code: E96; Power_W: 0.125; TempCo: 100 ppm/C; Tolerance_%: 1; U/M: EA; Value2: 0.125W; Value_Code: 100R; VDC_V: 150; Component Kind: Standard; ComponentLink1Description: Datasheet link; ComponentLink1URL: http://www.vishay.com/docs/20035/dcrcwe3.pdf; LatestRevisionDate: 2014-12-17; Library Name: Resistor_0805.IntLib; MountType: SMT; PackageReference: 0805; PartNumber: CRCW0805100RFKEA; Published: 2008-06-26; Res_ohm: 100; RoHS: Y; Signal Integrity: Resistor; Simulation: Resistor; Supplier 1: Digi-Key; Supplier 2: Mouser; Supplier 3: Farnell; Supplier Part Number 1: 541-100CCT-ND; Supplier Part Number 2: 71-CRCW0805-100-E3; Supplier Part Number 3: 1469862; SymbolVersion: 2009-05-29; Technology: Thick Film; Value1: 1%; ValueDisplayed: 100; Publisher: Texas Instruments
R14 = CRCW040216K2FKED: RES, 16.2 k, 1%, 0.063 W, 0402; Manufacturer: Vishay-Dale; CompType: Resistor; EIA_Code: E96; Power_W: 0.063; Res_ohm: 16200; TempCo: 100 ppm/C; Tolerance_%: 1; U/M: EA; Value2: 0.063W; Value_Code: 16k2; VDC_V: 50; Component Kind: Standard; ComponentLink1Description: Datasheet link; ComponentLink1URL: http://www.vishay.com/docs/20035/dcrcwe3.pdf; LatestRevisionDate: 2015-05-27; Library Name: Resistor_0402.IntLib; MountType: SMT; PackageReference: 0402; PartNumber: CRCW040216K2FKED; Published: 2008-06-26; RoHS: Y; Signal Integrity: Resistor; Simulation: Resistor; Supplier 1: Digi-Key; Supplier 2: Mouser; Supplier 3: Farnell; Supplier Part Number 1: 541-16.2KLCT-ND; Supplier Part Number 2: 71-CRCW0402-16.2K-E3; Supplier Part Number 3: 1469685; SymbolVersion: 2009-05-29; Technology: Thick Film; Value1: 1%; ValueDisplayed: 16.2k; Publisher: Texas Instruments
R15 = 2-2176057-7: RES, 0.12, 1%, 2 W, 2512; Manufacturer: TE Connectivity; CompType: Resistor; EIA_Code: E96; Power_W: 2; TempCo: 200 ppm/C; Tolerance_%: 1; U/M: EA; Value2: 2W; Value_Code: R120; Component Kind: Standard; ComponentLink1Description: Datasheet link; ComponentLink1URL: http://www.te.com/commerce/DocumentDelivery/DDEController?Action=showdoc&DocId=Customer+Drawing%7F2176057%7FBA%7Fpdf%7FEnglish%7FENG_CD_2176057_BA.pdf%7F2-2176057-7; LatestRevisionDate: 2015-04-21; Library Name: Resistor_2512.IntLib; MountType: SMT; PackageReference: 2512; PartNumber: 2-2176057-7; Published: 2008-06-26; RoHS: Y; Signal Integrity: Resistor; Simulation: Resistor; Supplier 1: Digi-Key; Supplier Part Number 1: A109696CT-ND; SymbolVersion: 2009-05-29; Technology: Thick Film; Value1: 1%; ValueDisplayed: 0.12; Publisher: Texas Instruments
R16 = CRCW06030000Z0EA: RES, 0, 5%, 0.1 W, 0603; Manufacturer: Vishay-Dale; CompType: Resistor; EIA_Code: E24; Power_W: 0.1; TempCo: 200 ppm/C; Tolerance_%: 5; U/M: EA; Value2: 0.1W; Value_Code: 0000; VDC_V: 75; Component Kind: Standard; ComponentLink1Description: Datasheet link; ComponentLink1URL: http://www.vishay.com/docs/20035/dcrcwe3.pdf; LatestRevisionDate: 2015-06-13; Library Name: Resistor_0603.IntLib; MountType: SMT; PackageReference: 0603; PartNumber: CRCW06030000Z0EA; Published: 2008-06-26; Res_ohm: 0; RoHS: Y; Signal Integrity: Resistor; Simulation: Resistor; Supplier 1: Digi-Key; Supplier 2: Mouser; Supplier 3: Farnell; Supplier Part Number 1: 541-0.0GCT-ND; Supplier Part Number 2: 71-CRCW0603-0-E3; Supplier Part Number 3: 1469739; SymbolVersion: 2009-05-29; Technology: Thick Film; Value1: 5%; ValueDisplayed: 0; Publisher: Texas Instruments
R17 = CRCW04021K82FKED: RES, 1.82 k, 1%, 0.063 W, 0402; Manufacturer: Vishay-Dale; CompType: Resistor; EIA_Code: E96; Power_W: 0.063; Res_ohm: 1820; TempCo: 100 ppm/C; Tolerance_%: 1; U/M: EA; Value2: 0.063W; Value_Code: 1k82; VDC_V: 50; Component Kind: Standard; ComponentLink1Description: Datasheet link; ComponentLink1URL: http://www.vishay.com/docs/20035/dcrcwe3.pdf; LatestRevisionDate: 2015-05-27; Library Name: Resistor_0402.IntLib; MountType: SMT; PackageReference: 0402; PartNumber: CRCW04021K82FKED; Published: 2008-06-26; RoHS: Y; Signal Integrity: Resistor; Simulation: Resistor; Supplier 1: Digi-Key; Supplier 2: Mouser; Supplier 3: Farnell; Supplier Part Number 1: 541-1.82KLCT-ND; Supplier Part Number 2: 71-CRCW0402-1.82K-E3; Supplier Part Number 3: 1158290; SymbolVersion: 2009-05-29; Technology: Thick Film; Value1: 1%; ValueDisplayed: 1.82k; Publisher: Texas Instruments
R19 = CPF0603B100KE: RES, 100 k, 0.1%, 0.063 W, 0603; Manufacturer: TE Connectivity; CompType: Resistor; EIA_Code: E192; Power_W: 0.063; Res_ohm: 100000; TempCo: 25 ppm/C; Tolerance_%: 0.1; U/M: EA; Value2: 0.063W; VDC_V: 50; Component Kind: Standard; ComponentLink1Description: Datasheet link; ComponentLink1URL: http://www.te.com/commerce/DocumentDelivery/DDEController?Action=showdoc&DocId=Data+Sheet%7F1773200%7FI%7Fpdf%7FEnglish%7FENG_DS_1773200_I.pdf%7F1-2176074-8; LatestRevisionDate: 2015-06-13; Library Name: Resistor_0603.IntLib; MountType: SMT; PackageReference: 0603; PartNumber: CPF0603B100KE; Published: 2008-06-26; RoHS: Y; Signal Integrity: Resistor; Simulation: Resistor; Supplier 1: Mouser; Supplier Part Number 1: 279-CPF0603B100KE; SymbolVersion: 2009-05-29; Technology: Thin Film; Value1: 0.1%; Value_Code: 100k; ValueDisplayed: 100k; Publisher: Texas Instruments
R20 = CRCW040228K7FKED: RES, 28.7 k, 1%, 0.063 W, 0402; Manufacturer: Vishay-Dale; CompType: Resistor; EIA_Code: E96; Power_W: 0.063; Res_ohm: 28700; TempCo: 100 ppm/C; Tolerance_%: 1; U/M: EA; Value2: 0.063W; Value_Code: 28k7; VDC_V: 50; Component Kind: Standard; ComponentLink1Description: Datasheet link; ComponentLink1URL: http://www.vishay.com/docs/20035/dcrcwe3.pdf; LatestRevisionDate: 2015-05-27; Library Name: Resistor_0402.IntLib; MountType: SMT; PackageReference: 0402; PartNumber: CRCW040228K7FKED; Published: 2008-06-26; RoHS: Y; Signal Integrity: Resistor; Simulation: Resistor; Supplier 1: Digi-Key; Supplier 2: Mouser; Supplier 3: Farnell; Supplier Part Number 1: 541-28.7KLCT-ND; Supplier Part Number 2: 71-CRCW0402-28.7K-E3; Supplier Part Number 3: 1151103; SymbolVersion: 2009-05-29; Technology: Thick Film; Value1: 1%; ValueDisplayed: 28.7k; Publisher: Texas Instruments
R25-R28 = CRCW08053K30JNEA: RES, 3.3 k, 5%, 0.125 W, 0805; Manufacturer: Vishay-Dale; CompType: Resistor; EIA_Code: E24; Power_W: 0.125; Res_ohm: 3300; TempCo: 200 ppm/C; Tolerance_%: 5; U/M: EA; Value2: 0.125W; Value_Code: 3k30; VDC_V: 150; Component Kind: Standard; ComponentLink1Description: Datasheet link; ComponentLink1URL: http://www.vishay.com/docs/20035/dcrcwe3.pdf; LatestRevisionDate: 2015-07-07; Library Name: Resistor_0805.IntLib; MountType: SMT; PackageReference: 0805; PartNumber: CRCW08053K30JNEA; Published: 2008-06-26; RoHS: Y; Signal Integrity: Resistor; Simulation: Resistor; Supplier 1: Digi-Key; Supplier 2: Mouser; Supplier 3: Farnell; Supplier Part Number 1: 541-3.3KACT-ND; Supplier Part Number 2: 71-CRCW08053K30JNEA; Supplier Part Number 3: 1514847; SymbolVersion: 2009-05-29; Technology: Thick Film; Value1: 5%; ValueDisplayed: 3.3k; Publisher: Texas Instruments
R30-R32 = CMF504K7500FHEB: RES, 18 k, 5%, 3W, TH; Manufacturer: Vishay-Dale; CompType: Resistor; EIA_Code: E96; Power_W: 0.25; Res_ohm: 4750; TempCo: 50 ppm/C; Tolerance_%: 1; U/M: EA; Value_Code: 4k75; VDC_V: 200; Component Kind: Standard; LatestRevisionDate: 2015-07-21; Library Name: Resistor_TH.IntLib; MountType: TH; PackageReference: 1/4W Resistor; PartNumber: ERG-3SJ183; Published: 2013-01-08; RoHS: Y; Signal Integrity: Resistor; Simulation: Resistor; Supplier 1: Digi-Key; Supplier Part Number 1: P18KW-3BK-ND; SymbolVersion: 2009-05-29; Technology: Metal Film; Value1: 5%; Value2: 3W; ValueDisplayed: 18K; Publisher: Texas Instruments
R33 = CMF504K7500FHEB: RES, 16k, 5%, 3W, TH; Manufacturer: Vishay-Dale; CompType: Resistor; EIA_Code: E96; Power_W: 0.25; Res_ohm: 4750; TempCo: 50 ppm/C; Tolerance_%: 1; U/M: EA; Value_Code: 4k75; VDC_V: 200; Component Kind: Standard; LatestRevisionDate: 2015-07-21; Library Name: Resistor_TH.IntLib; MountType: TH; PackageReference: 1/4W Resistor; PartNumber: ERG-3SJ163; Published: 2013-01-08; RoHS: Y; Signal Integrity: Resistor; Simulation: Resistor; Supplier 1: Digi-Key; Supplier Part Number 1: P16KW-3BK-ND; SymbolVersion: 2009-05-29; Technology: Metal Film; Value1: 5%; Value2: 3W; ValueDisplayed: 16k; Publisher: Texas Instruments
RT1 = B57153S150M: Thermistor NTC, 15 ohm, 20%, 8.5mm Disc; Manufacturer: EPCOS Inc; Power_W: 1.4; TaMax_C: 170; TaMin_C: -55; Tolerance_%: 20; U/M: EA; Component Kind: Standard; ComponentLink1Description: Datasheet link; ComponentLink1URL: http://www.epcos.com/inf/50/db/icl_12/NTC_ICL_S153.pdf; CompType: ThermistorNTC; LatestRevisionDate: 2014-10-06; Library Name: ThermistorNTC.IntLib; MountType: TH; PackageReference: 8.5mm Disc; PartNumber: B57153S150M; Published: 2009-09-22; RoHS: Y; Series: S153; Supplier 1: Digi-Key; Supplier 2: Mouser; Supplier 3: Farnell; Supplier Part Number 1: 495-2077-ND; Supplier Part Number 2: 871-B57153S150M; Supplier Part Number 3: 1688796; SymbolVersion: 2008-11-25; Publisher: Texas Instruments
RT2 = B57164K474J: Thermistor NTC, 470k, 5%, Disc, 5.5x5mm; Manufacturer: TDK; Power_W: 0.45; TaMax_C: 125; TaMin_C: -55; Tolerance_%: 5; U/M: EA; Component Kind: Standard; ComponentLink1Description: Datasheet link; ComponentLink1URL: http://www.epcos.com/inf/50/db/ntc_09/LeadedDisks__B57164__K164.pdf; CompType: ThermistorNTC; LatestRevisionDate: 2015-06-01; Library Name: ThermistorNTC.IntLib; MountType: TH; PackageReference: Disc, 5.5x5mm; PartNumber: B57164K474J; Published: 2009-09-22; RoHS: Y; Series: B57164K; Supplier 1: Digi-Key; Supplier 2: Mouser; Supplier 3: Farnell; Supplier Part Number 1: 495-2084-ND; Supplier Part Number 2: 871-B57164K474J; Supplier Part Number 3: 9753451; SymbolVersion: 2008-11-25; Publisher: Texas Instruments
RV1 = B72214S0271K101: Varistor, 430V, 4.5KA, TH; Manufacturer: EPCOS Inc; SymbolCreator: a0412235; Component Kind: Standard; ComponentLink1Description: Datasheet URL; ComponentLink1URL: http://www.epcos.com/inf/70/db/var_08/SIOV_Leaded_StandarD.pdf; CompType: Varistor; LatestRevisionDate: 2014-12-17; Library Name: Other_Passive.IntLib; MountType: TH; PackageReference: Disc, 14x5mm; PartNumber: B72214S0271K101; RoHS: Y; Supplier 1: Digi-Key; Supplier 2: Mouser; Supplier 3: Farnell; Supplier Part Number 1: 495-1412-ND; Supplier Part Number 2: 871-B72214S271K101; Supplier Part Number 3: 1004362; SymbolVersion: 2012-06-04; Publisher: Texas Instruments
T1 = 750342946: Transformer, 300 uH, TH; Manufacturer: Wurth Elektronik; SymbolCreator: Altium; U/M: EA; Value: 300 uH; Component Kind: Standard; ComponentLink1Description: Datasheet Link; ComponentLink1URL: https://sps06.itg.ti.com/sites/KMS/crossdivteams/altium/docs/ComponentDatasheets/750342946r00.pdf; CompType: Transformer; LatestRevisionDate: 2015-07-25; MountType: TH; PackageReference: 37.34x35.56mm; PartNumber: 750342946; RoHS: Y; SymbolVersion: 2015-07-23; Library Name: TIDA-00367.SCHLIB; Publisher: Texas Instruments
U1 = TLV1117CKVU: ADJUSTABLE AND FIXED LDO VOLTAGE REGULATOR, KVU0003A; Alternate Manufacturer: None; Manufacturer: Texas Instruments; CompType: IC; SymbolCreator: a0799628; U/M: EA; Component Kind: Standard; ComponentLink1Description: Datasheet Link; ComponentLink1URL: http://www.ti.com/lit/ds/symlink/tlv1117.pdf; LatestRevisionDate: 2014-07-16; MountType: SMT; PackageReference: KVU0003A; PartNumber: TLV1117CKVU; RoHS: Y; SymbolVersion: 2013-10-16; Library Name: TIDA-00434.SCHLIB; Publisher: Texas Instruments
U2 = UCC28630D: High-Power Flyback Controller with Primary-Side Regulation and Peak-Power Mode, D0007A; Alternate Manufacturer: Texas Instruments; CompType: IC; SymbolCreator: Altium; U/M: EA; Component Kind: Standard; ComponentLink1Description: Datasheet Link; ComponentLink1URL: http://www.ti.com/lit/ds/symlink/ucc28630.pdf; LatestRevisionDate: 2015-06-02; Library Name: TI_Pre_Release.IntLib; Manufacturer: Texas Instruments; MountType: SMT; PackageReference: D0007A; PartNumber: UCC28630D; RoHS: Y; SymbolVersion: 2014-10-27; Publisher: Texas Instruments
